# Altium schematic sheet TRINKIEROCKS P5.SchDoc
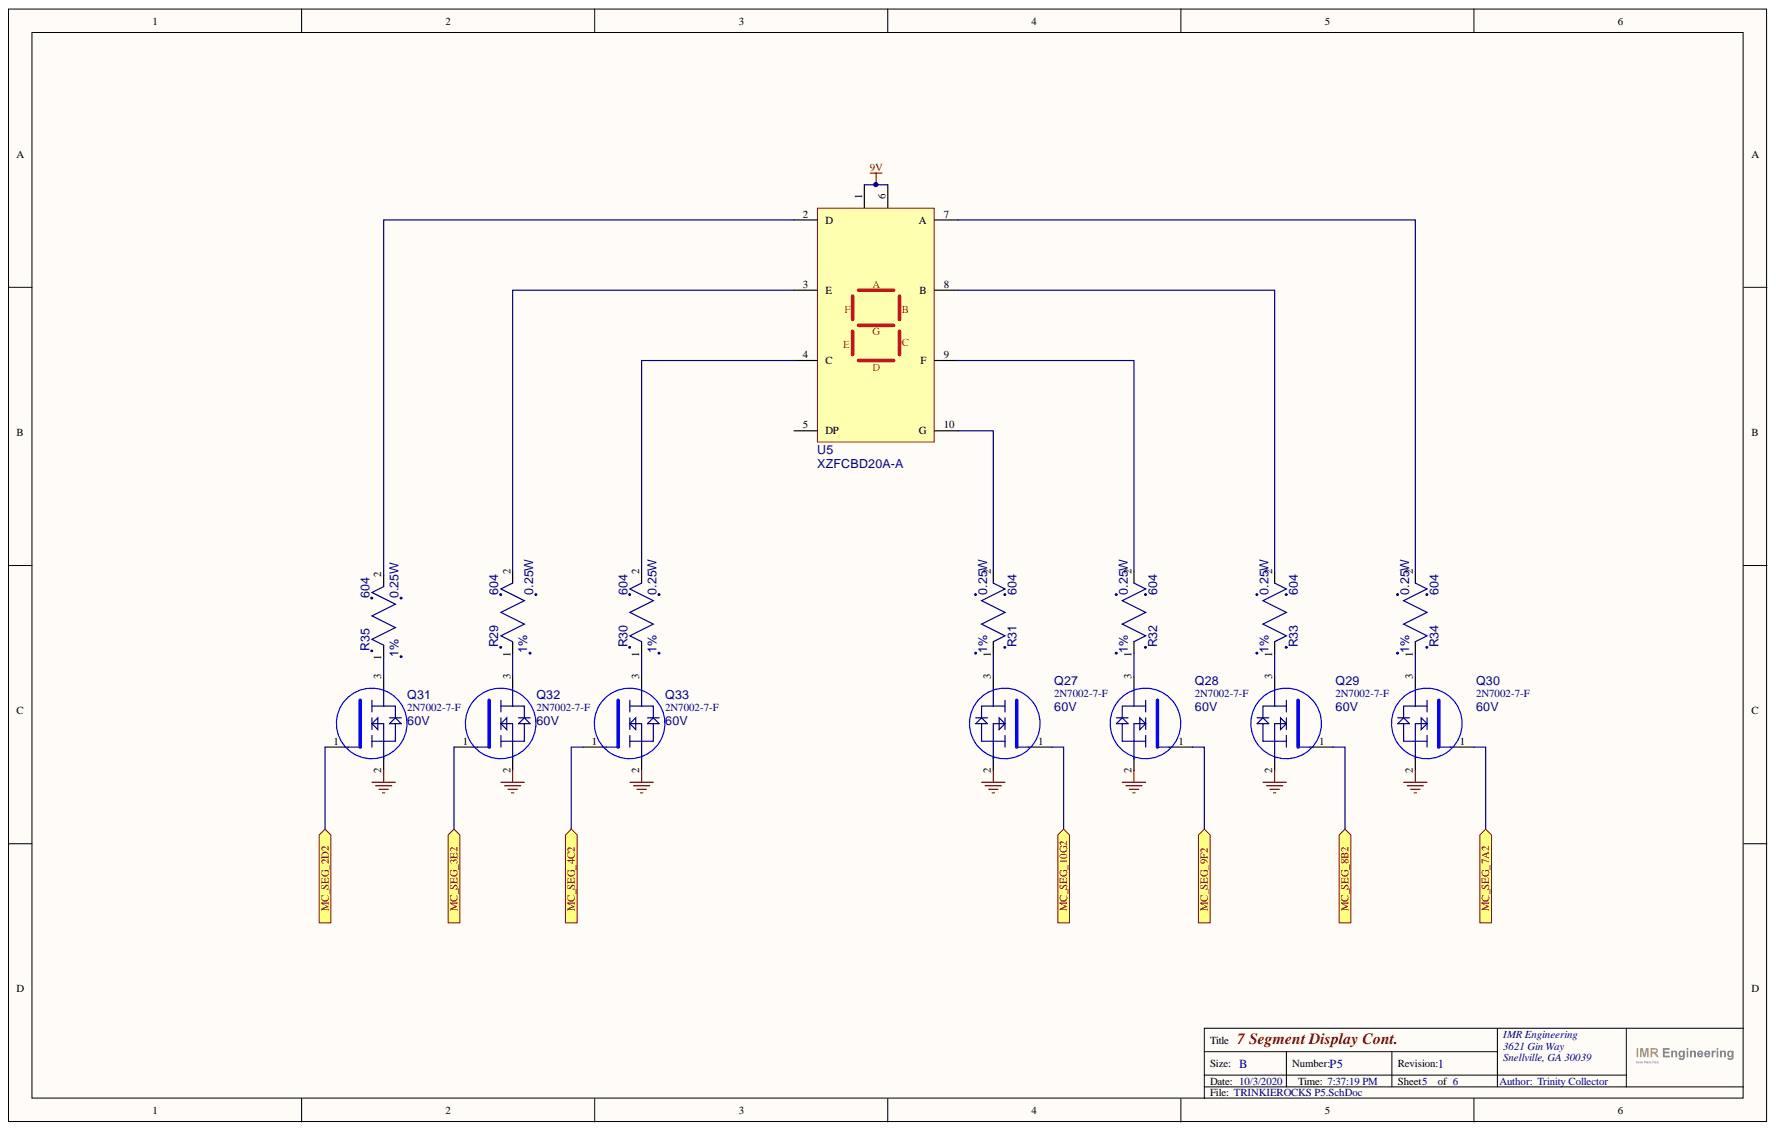
[diagram p0: TRINKIEROCKS P5.SchDoc]

## Parts
Q27  2N7002-7-F  value 60V  [SOT23 - TRANSISTOR]
Q28  2N7002-7-F  value 60V  [SOT23 - TRANSISTOR]
Q29  2N7002-7-F  value 60V  [SOT23 - TRANSISTOR]
Q30  2N7002-7-F  value 60V  [SOT23 - TRANSISTOR]
Q31  2N7002-7-F  value 60V  [SOT23 - TRANSISTOR]
Q32  2N7002-7-F  value 60V  [SOT23 - TRANSISTOR]
Q33  2N7002-7-F  value 60V  [SOT23 - TRANSISTOR]
R29  value 604  POWER: 0.25W  TOLERANCE: 1%  [RES_0805]  (hidden comment: RK73H2ATTD6040F)
R30  value 604  POWER: 0.25W  TOLERANCE: 1%  [RES_0805]  (hidden comment: RK73H2ATTD6040F)
R31  value 604  POWER: 0.25W  TOLERANCE: 1%  [RES_0805]  (hidden comment: RK73H2ATTD6040F)
R32  value 604  POWER: 0.25W  TOLERANCE: 1%  [RES_0805]  (hidden comment: RK73H2ATTD6040F)
R33  value 604  POWER: 0.25W  TOLERANCE: 1%  [RES_0805]  (hidden comment: RK73H2ATTD6040F)
R34  value 604  POWER: 0.25W  TOLERANCE: 1%  [RES_0805]  (hidden comment: RK73H2ATTD6040F)
R35  value 604  POWER: 0.25W  TOLERANCE: 1%  [RES_0805]  (hidden comment: RK73H2ATTD6040F)
U5  XZFCBD20A-A  [7 SEG]

## Ports
MC_SEG_2D2, MC_SEG_3E2, MC_SEG_4C2, MC_SEG_7A2, MC_SEG_8B2, MC_SEG_9F2, MC_SEG_10G2

## Nets
9V: U5.1 U5.6
GND: Q27.2 Q28.2 Q29.2 Q30.2 Q31.2 Q32.2 Q33.2
MC_SEG_2D2: Q31.1 ; port MC_SEG_2D2
MC_SEG_3E2: Q32.1 ; port MC_SEG_3E2
MC_SEG_4C2: Q33.1 ; port MC_SEG_4C2
MC_SEG_7A2: Q30.1 ; port MC_SEG_7A2
MC_SEG_8B2: Q29.1 ; port MC_SEG_8B2
MC_SEG_9F2: Q28.1 ; port MC_SEG_9F2
MC_SEG_10G2: Q27.1 ; port MC_SEG_10G2
NetQ27_3: Q27.3 R31.1
NetQ28_3: Q28.3 R32.1
NetQ29_3: Q29.3 R33.1
NetQ30_3: Q30.3 R34.1
NetQ31_3: Q31.3 R35.1
NetQ32_3: Q32.3 R29.1
NetQ33_3: Q33.3 R30.1
NetR29_2: R29.2 U5.3(E)
NetR30_2: R30.2 U5.4(C)
NetR31_2: R31.2 U5.10(G)
NetR32_2: R32.2 U5.9(F)
NetR33_2: R33.2 U5.8(B)
NetR34_2: R34.2 U5.7(A)
NetR35_2: R35.2 U5.2(D)

Not connected: U5 5(DP)

## Library data of the parts
Q27-Q33 = MOSFET_NCH_2N7002_115MA: MOSFET N CHN, VDS=60V, 115 mA, SOT 23; MFG: DIODES INC; Case/Package: SOT23; Component Kind: Standard; MFG_PN: 2N7002-7-F; Supplier 1: Digi-Key; Supplier Part Number 1: 2N7002-FDICT-ND; Library Name: Trinkie.SchLib
R29-R35 = RES_604_0805_1/4W_1%: RES 604 OHM 1% 1/4W 0805; MFG: KOA Speer Electronics, Inc.; Case/Package: 0805; Component Kind: Standard; MFG_PN: RK73H2ATTD6040F; Supplier 1: Digi-Key; Supplier Part Number 1: 2019-RK73H2ATTD6040FCT-ND; Library Name: Trinkie.SchLib
U5 = DISPLAY_7_SEG_LED_3V_10MA: DISPLAY 0.8" 1DIGIT BLUE CA SMD; MFG: SunLED; Case/Package: 10-SMD; Component Kind: Standard; MFG_PN: XZFCBD20A-A; Supplier 1: Digi-Key; Supplier Part Number 1: 1497-1486-1-ND; VALUE: XZFCBD20A-A; Library Name: Trinkie.SchLib
